# Altium schematic sheet Connections.SchDoc
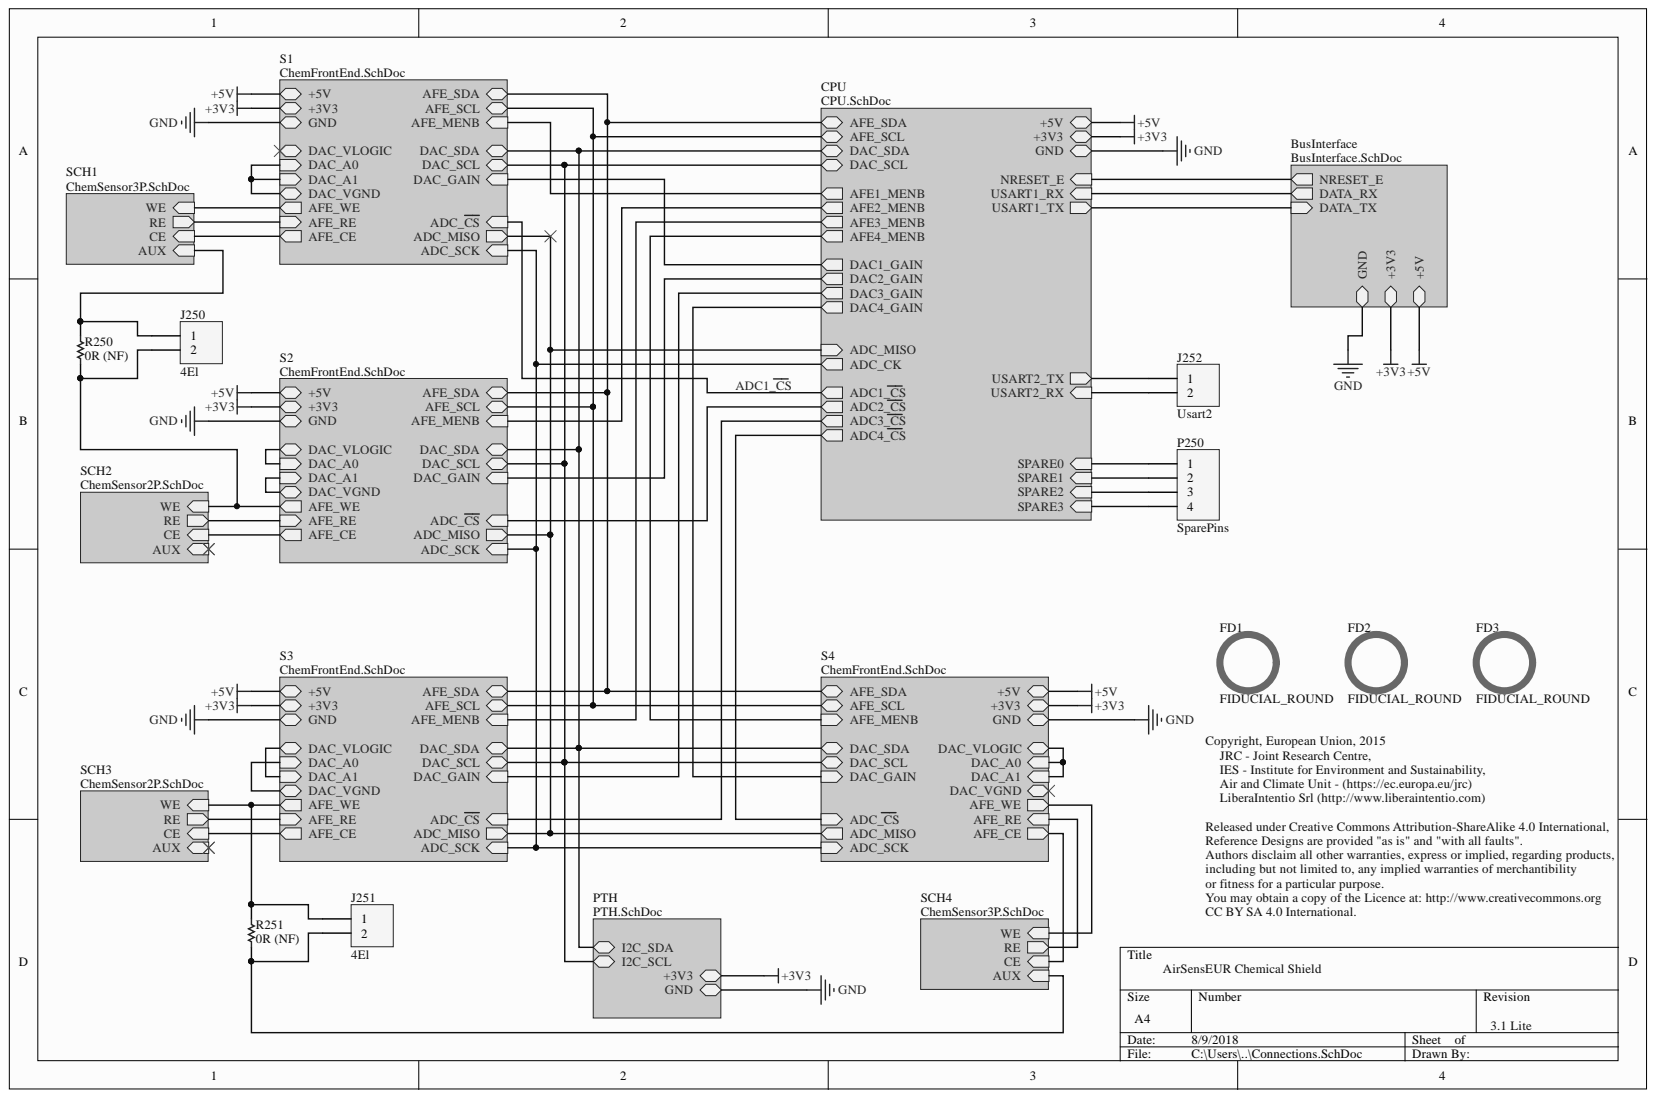
[diagram p0: Connections.SchDoc]

## Parts
FD1  FIDUCIAL_ROUND  [FIDUCIAL_ROUND]
FD2  FIDUCIAL_ROUND  [FIDUCIAL_ROUND]
FD3  FIDUCIAL_ROUND  [FIDUCIAL_ROUND]  (no BOM)
J250  4El  [HDR1X2]
J251  4El  [HDR1X2]
J252  Usart2  [HDR1X2]
P250  SparePins  [HDR1X4]
R250  value 0R (NF)  [RESC0603(1608)_L]  (hidden comment: 0R NF 0603(1608))
R251  value 0R (NF)  [RESC0603(1608)_L]  (hidden comment: 0R NF 0603(1608))

## Nets
NetJ250_1: J250.1 R250.1
NetJ250_2: J250.2 R250.2
NetJ251_1: J251.1 R251.2
NetJ251_2: J251.2 R251.1
NetJ252_1: J252.1
NetJ252_2: J252.2
NetP250_1: P250.1
NetP250_2: P250.2
NetP250_3: P250.3
NetP250_4: P250.4
+3V3:
+5V:
ADC1_~{CS}:
ADC2_~{CS}:
ADC3_~{CS}:
ADC4_~{CS}:
ADC_CK:
ADC_MISO:
AFE1_MENB:
AFE2_MENB:
AFE3_MENB:
AFE4_MENB:
AFE_CE:
AFE_RE:
AFE_SCL:
AFE_SDA:
AFE_WE:
AUX:
DAC1_GAIN:
DAC2_GAIN:
DAC3_GAIN:
DAC4_GAIN:
DAC_A0:
DAC_A1:
DAC_SCL:
DAC_SDA:
DAC_VGND:
DAC_VLOGIC:
DATA_RX:
DATA_TX:
GND:
NRESET_E:

## Library data of the parts
FD1-FD3 = CMP-0486-00001-2: Fiducial - Round 1.5 mm Top-Bottom; Manufacturer: No Vendor; Library Name: Altium Content Vault; PartNumber: FIDUCIAL_ROUND
  FD1 FD2: Component Kind: Standard
J250-J252 = Header 2: Header, 2-Pin; Component Kind: Standard; LatestRevisionDate: 17-Jul-2002; LatestRevisionNote: Re-released for DXP Platform.; Library Name: Miscellaneous Connectors.IntLib; Publisher: Altium Limited
P250 = Header 4: Header, 4-Pin; Component Kind: Standard; LatestRevisionDate: 17-Jul-2002; LatestRevisionNote: Re-released for DXP Platform.; Library Name: Miscellaneous Connectors.IntLib; Publisher: Altium Limited
R250 R251 = CMP-1012-00001-1: Jumper 0603 (1608 Metric); Case-EIA: 0603; Case-Metric: 1608; Power: None; Component Kind: Standard; Library Name: Altium Content Vault; Technology: SMT; Tolerance: None
